# Altium schematic sheet OBDII_PinTester.SchDoc(OBDII_PinTester)
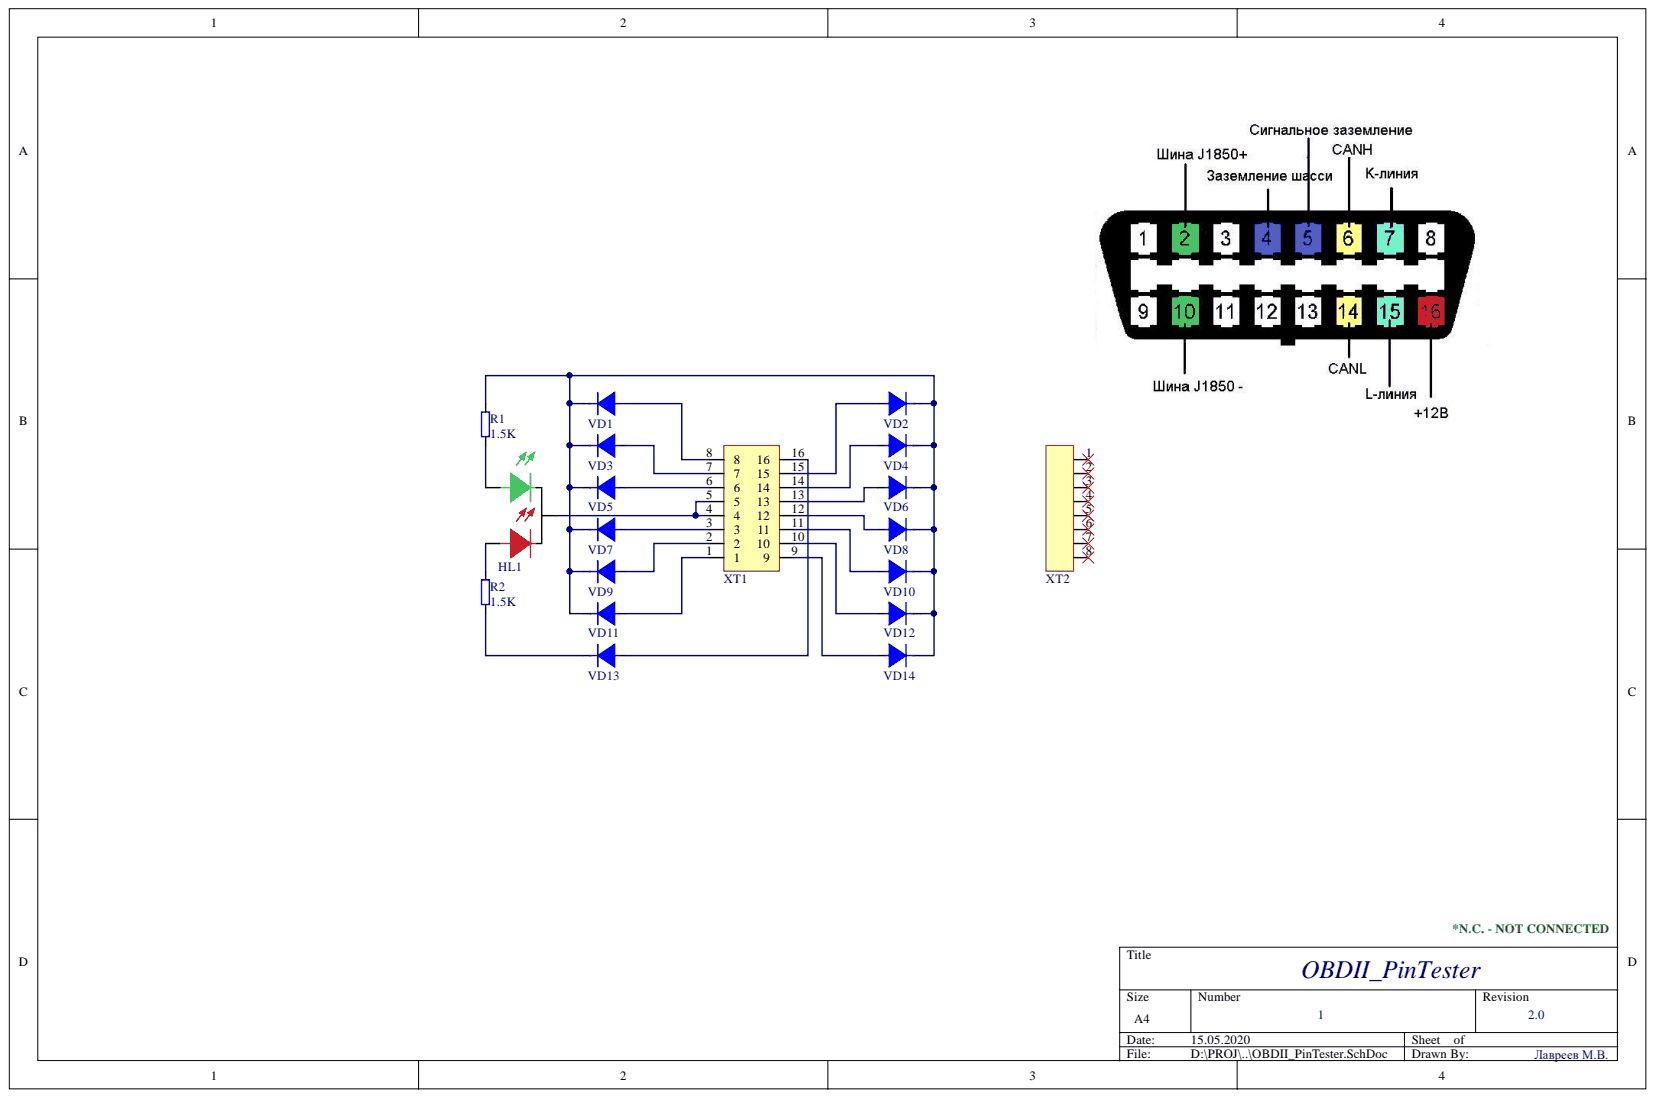
[diagram p0: OBDII_PinTester.SchDoc]

## Parts
HL1  LED_RG  [LED_5mm_3pins_R]  (value not drawn)
R1  1.5K  [RES_0805]
R2  1.5K  [RES_0805]
VD1  (no value)  [SMA]
VD2  (no value)  [SMA]
VD3  (no value)  [SMA]
VD4  (no value)  [SMA]
VD5  (no value)  [SMA]
VD6  (no value)  [SMA]
VD7  (no value)  [SMA]
VD8  (no value)  [SMA]
VD9  (no value)  [SMA]
VD10  (no value)  [SMA]
VD11  (no value)  [SMA]
VD12  (no value)  [SMA]
VD13  (no value)  [SMA]
VD14  (no value)  [SMA]
XT1  (no value)  [PLD-8_OBD]
XT2  (no value)  [PLS-8_SMD]

## Nets
NetHL1_1: HL1.1 R2.2
NetHL1_2: HL1.2 XT1.4 XT1.5
NetHL1_3: HL1.3 R1.1
NetR1_2: R1.2 VD1.2 VD2.2 VD3.2 VD4.2 VD5.2 VD6.2 VD7.2 VD8.2 VD9.2 VD10.2 VD11.2 VD12.2 VD14.2
NetR2_1: R2.1 VD13.2
NetVD1_1: VD1.1 XT1.8
NetVD2_1: VD2.1 XT1.15
NetVD3_1: VD3.1 XT1.7
NetVD4_1: VD4.1 XT1.14
NetVD5_1: VD5.1 XT1.6
NetVD6_1: VD6.1 XT1.13
NetVD7_1: VD7.1 XT1.3
NetVD8_1: VD8.1 XT1.12
NetVD9_1: VD9.1 XT1.2
NetVD10_1: VD10.1 XT1.11
NetVD11_1: VD11.1 XT1.1
NetVD12_1: VD12.1 XT1.10
NetVD13_1: VD13.1 XT1.16
NetVD14_1: VD14.1 XT1.9

Not connected: XT2 1 2 3 4 5 6 7 8

## Library data of the parts
HL1 = LED_RG: LED Red + Green Common Cathode; Component Kind: Standard; Library Name: DIODE.SchLib
R1 R2 = RES_SMD: Chip Resistor; Tolerance: ±5%; Component Kind: Standard; Library Name: RESISTOR.SchLib; Value: 1.5K
VD1-VD14 = Diode: Component Kind: Standard; Description: Diode; Library Name: DIODE.SchLib
XT1 = OBDII: Component Kind: Standard; Description: OBDII; Library Name: CONNECTOR_MISC.SchLib
XT2 = PLS-8: Header Connector; Number of Positions: 8; Row Spacing: 2.54mm; Component Kind: Standard; Connector Type: Header; Contact Type: Male; Library Name: CONNECTOR_HEADER.SchLib; Number of Rows: 1
